# Altium schematic sheet POWER.SchDoc(POWER)
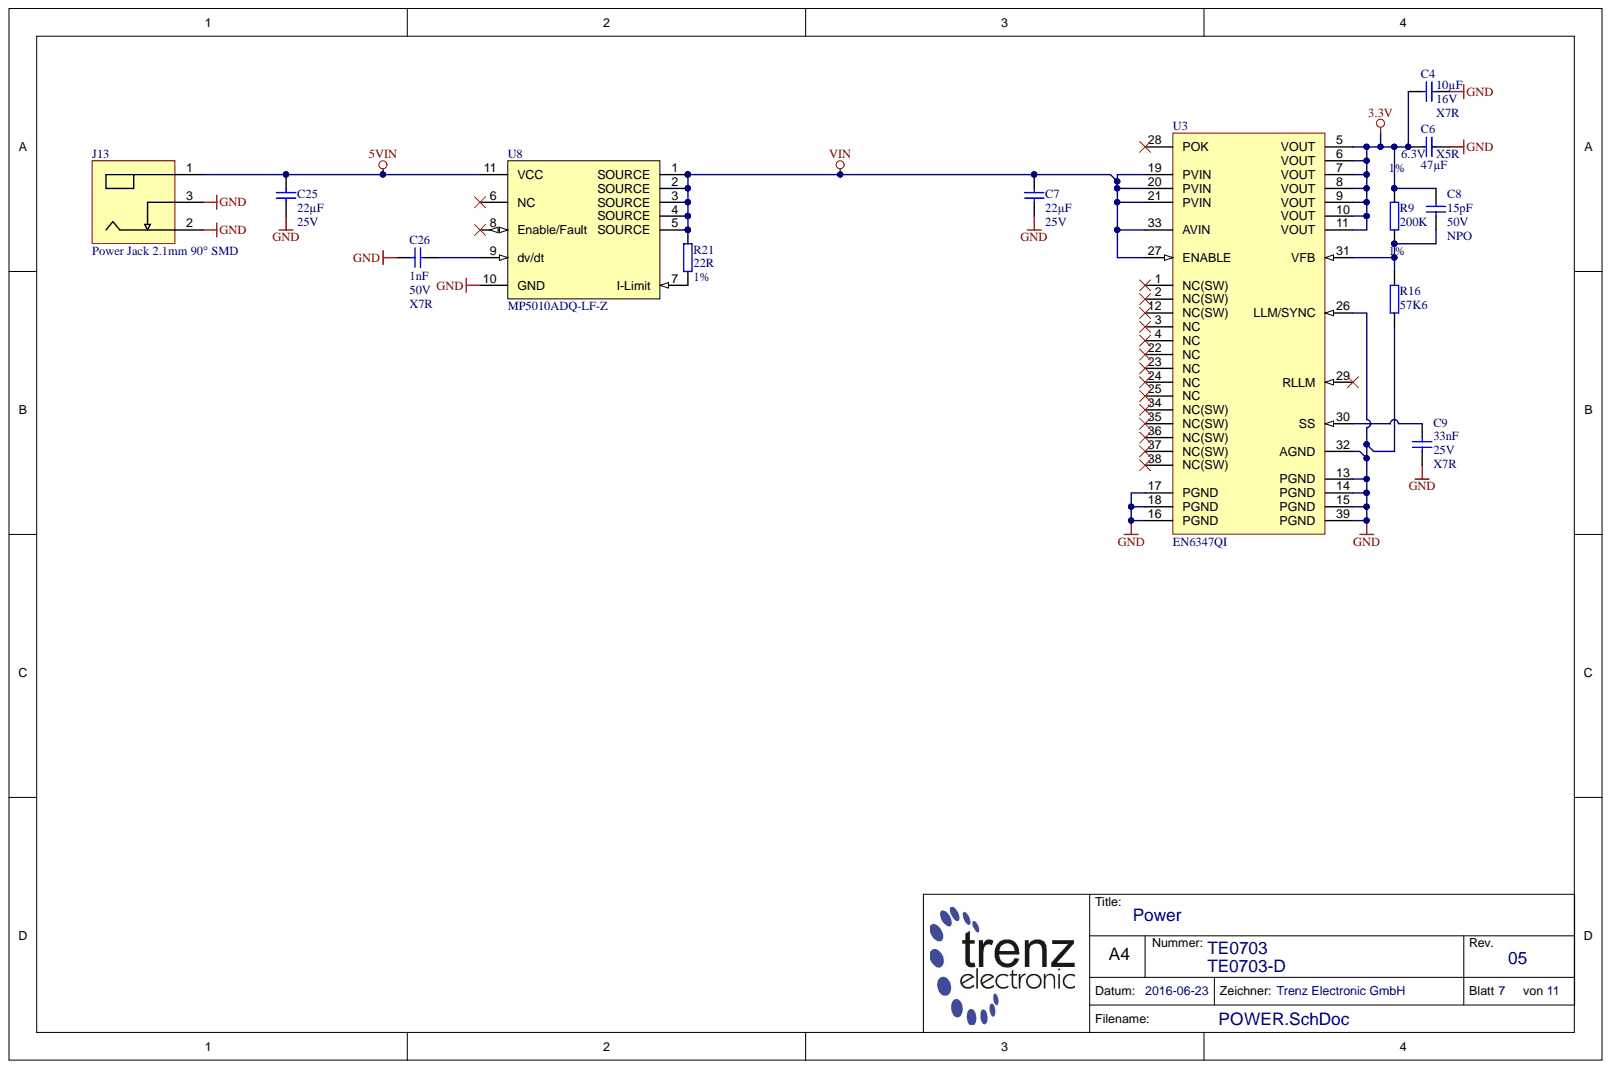
[diagram p0: POWER.SchDoc]

## Parts
C4  10µF  Technology: X7R  Voltage: 16V  [CAPC2012X135N]
C6  47µF  Technology: X5R  Voltage: 6.3V  [CAPC3216X180N]
C7  22µF  Voltage: 25V  [CAPC3216X180N]
C8  15pF  Technology: NPO  Voltage: 50V  [CAPC1005X55N]
C9  33nF  Technology: X7R  Voltage: 25V  [CAPC1005X55N]
C25  22µF  Voltage: 25V  [CAPC3216X180N]
C26  1nF  Voltage: 50V  [CAPC1005X55N]
J13  Power Jack 2.1mm 90° SMD  [694106106102]
R9  200K  Tolerance: 1%  [RESC1005X40N]
R16  57K6  [RESC1005X40N]
R21  22R  Tolerance: 1%  [RESC1005X40N]
U3  EN6347QI  [EN63xx]
U8  MP5010ADQ-LF-Z  [SON50P300X300X100-11N]

## Nets
3.3V: C4.1 C6.1 C8.1 R9.1 U3.5(VOUT) U3.6(VOUT) U3.7(VOUT) U3.8(VOUT) U3.9(VOUT) U3.10(VOUT) U3.11(VOUT)
5VIN: C25.1 J13.1 U8.11(VCC)
GND: C4.2 C6.2 C7.1 C9.2 C25.2 C26.1 J13.2 J13.3 R16.2 U3.13(PGND) U3.14(PGND) U3.15(PGND) U3.16(PGND) U3.17(PGND) U3.18(PGND) U3.26(LLM/SYNC) U3.32(AGND) U3.39(PGND) U8.10(GND)
VIN: C7.2 R21.1 U3.19(PVIN) U3.20(PVIN) U3.21(PVIN) U3.27(ENABLE) U3.33(AVIN) U8.1(SOURCE) U8.2(SOURCE) U8.3(SOURCE) U8.4(SOURCE) U8.5(SOURCE)
NetC8_2: C8.2 R9.2 R16.1 U3.31(VFB)
NetC9_1: C9.1 U3.30(SS)
NetC26_2: C26.2 U8.9(dv/dt)
NetR21_2: R21.2 U8.7(I-Limit)

Not connected: U3 1(NC(SW)) 2(NC(SW)) 3(NC) 4(NC) 12(NC(SW)) 22(NC) 23(NC) 24(NC) 25(NC) 28(POK) 29(RLLM) 34(NC(SW)) 35(NC(SW)) 36(NC(SW)) 37(NC(SW)) 38(NC(SW)); U8 6(NC) 8(Enable/Fault)

## Library data of the parts
C4 = 24380: KON 10µF 16V X7R SMD 10%; Database Table Name: C_2012_0805; Mixed: SMT; Preferred: Yes; Value: 10u; AN: 24380; Case: 2012 (0805); Component Kind: Standard; Library Name: TE.DbLib; Tolerance: 10%
C6 = 24557: KON 47µF 6.3V  X5R SMD 20%; Database Table Name: C_3216_1206; Mixed: SMT; Preferred: Yes; Value: 47u; AN: 24557; Case: 3216 (1206); Component Kind: Standard; Library Name: TE.DbLib; Tolerance: 20%
C7 C25 = 24886: KON 22µF X5R 25V SMD 10%; Database Table Name: C_3216_1206; Mixed: SMT; Preferred: Yes; Value: 22u; AN: 24886; Case: 3216 (1206); Component Kind: Standard; Library Name: TE.DbLib; Tolerance: 10%
C8 = 23951: KON 15pF NPO 50V SMD; Database Table Name: C_1005_0402; Mixed: SMT; Preferred: Yes; Value: 15p; AN: 23951; Case: 1005 (0402); Component Kind: Standard; Library Name: TE.DbLib
C9 = 23916: KON 33nF 25V X7R SMD; Database Table Name: C_1005_0402; Mixed: SMT; Preferred: Yes; Value: 33n; AN: 23916; Case: 1005 (0402); Component Kind: Standard; Library Name: TE.DbLib
C26 = 22471: KON 1nF 50V X7R SMD; Database Table Name: C_1005_0402; Mixed: SMT; Preferred: Yes; Tolerance: 10%; Value: 1n; AN: 22471; Case: 1005 (0402); Component Kind: Standard; Library Name: TE.DbLib; Technology: X7R
J13 = 26873: Database Table Name: CON_PWR; Mixed: SMT; Trenz-Stock: Yes; AN: 26873; Component Kind: Standard; Description: Power Jack 2.1mm 90° SMD; H-ART: 694106106102; Library Name: TE.DbLib; HelpURL: B:\ad\adlib\datasheet\694106106102
R9 = 23846: WID SMD 200K 1% 0,063W; Database Table Name: R_1005_0402; Mixed: SMT; Preferred: Yes; AN: 23846; Case: 1005 (0402); Component Kind: Standard; Library Name: TE.DbLib; Technology: TK100; Value: 200K
R16 = 24958: WID SMD 57K6 1% 0,063W; Database Table Name: R_1005_0402; Mixed: SMT; Preferred: Yes; Value: 57.6K; AN: 24958; Case: 1005 (0402); Component Kind: Standard; Library Name: TE.DbLib; Technology: TK100; Tolerance: 1%
R21 = 25892: WID SMD 22R 1% 0,063W; Database Table Name: R_1005_0402; Mixed: SMT; Preferred: Yes; Value: 22; AN: 25892; Case: 1005 (0402); Component Kind: Standard; Library Name: TE.DbLib; Technology: TK100
U3 = 24486: Database Table Name: DCDC; Mixed: SMT; Preferred: Yes; AN: 24486; Component Kind: Standard; Description: EN6347QI; H-ART: EN6347QI; Library Name: TE.DbLib; Trenz-Stock: Yes; HelpURL: B:\AD\adlib\datasheet\EN6347QI
U8 = 25620: Database Table Name: SWITCH; Mixed: SMT; Voltage: 5V; AN: 25620; Case: DFN10; Component Kind: Standard; Description: MP5010ADQ-LF-Z; H-ART: MP5010ADQ-LF-Z; Library Name: TE.DbLib; HelpURL: B:\AD\adlib\datasheet\MP5010
